# Altium schematic sheet RB_Audio.SchDoc(RB_Audio)
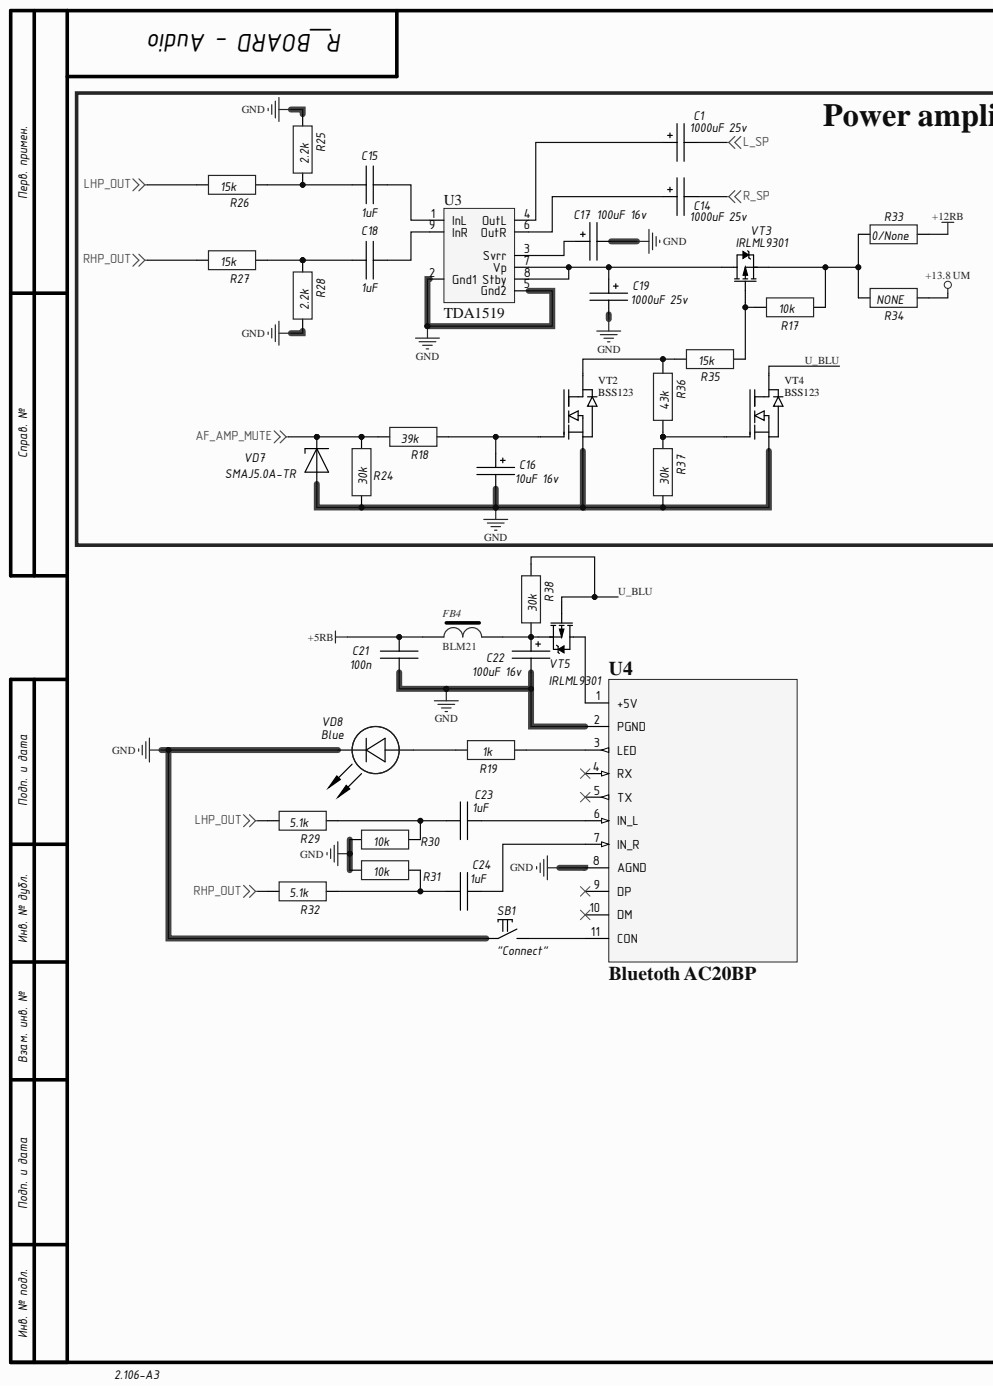
[diagram p0: RB_Audio.SchDoc, left]
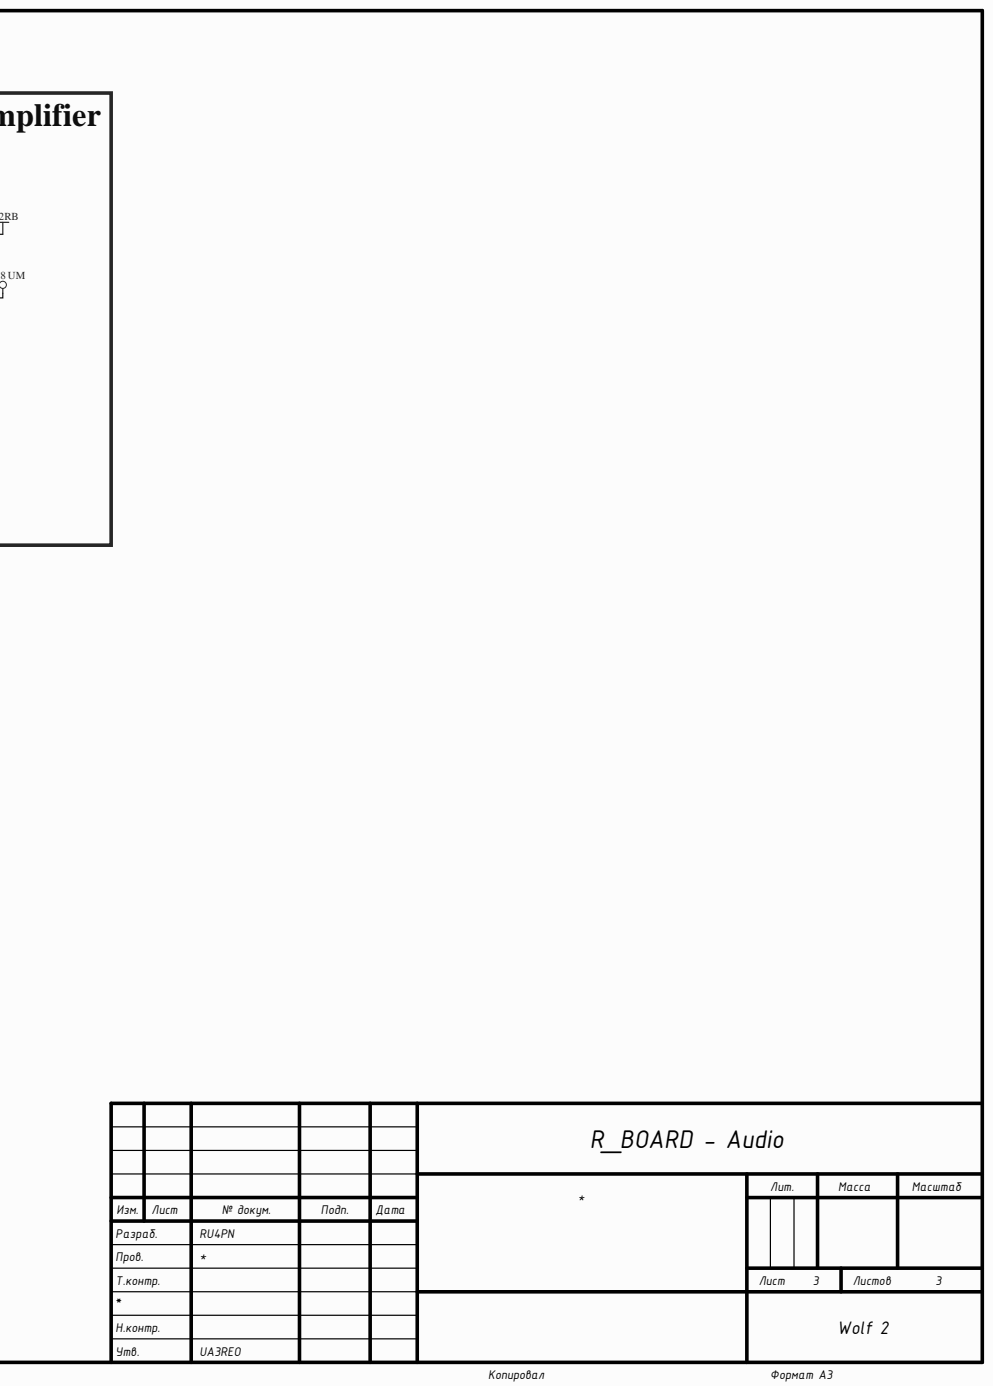
[diagram p1: RB_Audio.SchDoc, right]

## Parts
C1  1000uF 25v  [D10C5H12.5]
C14  1000uF 25v  [D10C5H12.5]
C15  1uF  [CH0805C]
C16  10uF 16v  [TANTAL_B]
C17  100uF 16v  [TANTAL_D]
C18  1uF  [CH0805C]
C19  1000uF 25v  [D10C5H12.5]
C21  100n  [CH0805C]
C22  100uF 16v  [D6.3C2.5H11]
C23  1uF  [CH0805C]
C24  1uF  [CH0805C]
FB4  BLM21  [CH0805L]
R17  10k  [CH0805R]
R18  39k  [CH0805R]
R19  1k  [CH0805R]
R24  30k  [CH0805R]
R25  2.2k  [CH0805R]
R26  15k  [CH0805R]
R27  15k  [CH0805R]
R28  2.2k  [CH0805R]
R29  5.1k  [CH0805R]
R30  10k  [CH0805R]
R31  10k  [CH0805R]
R32  5.1k  [CH0805R]
R33  0/None  [CH1206R]
R34  NONE  [CH1206R]
R35  15k  [CH0805R]
R36  43k  [CH0805R]
R37  30k  [CH0805R]
R38  30k  [CH0805R]
SB1  "Connect"  [BUT: 6x6 mm (R)]
U3  TDA1519  [SOT110B]
U4  Bluetoth AC20BP  [Bluetooth 11PIN]
VD7  SMAJ5.0A-TR  [SMA]
VD8  Blue  [CH0805LB]
VT2  BSS123  [SOT23_132]
VT3  IRLML9301  [SOT23_132]
VT4  BSS123  [SOT23_132]
VT5  IRLML9301  [SOT23_132]

## Nets
+5RB: C21.2 FB4.2
+12RB: R33.2
+13.8 UM: R34.2
AF_AMP_MUTE: R18.1 R24.2 VD7.2
GND: C16.2 C17.2 C19.2 C21.1 C22.2 R24.1 R25.2 R28.1 R30.1 R31.1 R37.1 SB1.1 U3.2(Gnd1) U3.5(Gnd2) U4.2(PGND) U4.8(AGND) VD7.1 VD8.2 VT2.2 VT4.2
L_SP: C1.2
LHP_OUT: R26.1 R29.1
R_SP: C14.2
RHP_OUT: R27.1 R32.1
U_BLU: R38.2 VT4.3 VT5.1
NetC1_1: C1.1 U3.4(OutL)
NetC14_1: C14.1 U3.6(OutR)
NetC15_1: C15.1 R25.1 R26.2
NetC15_2: C15.2 U3.1(InL)
NetC16_1: C16.1 R18.2 VT2.1
NetC17_1: C17.1 U3.3(Svrr)
NetC18_1: C18.1 R27.2 R28.2
NetC18_2: C18.2 U3.9(InR)
NetC19_1: C19.1 U3.7(Vp) U3.8(Stby) VT3.3
NetC22_1: C22.1 FB4.1 VT5.2
NetC23_1: C23.1 R29.2 R30.2
NetC23_2: C23.2 U4.6(IN_L)
NetC24_1: C24.1 R31.2 R32.2
NetC24_2: C24.2 U4.7(IN_R)
NetR17_1: R17.1 R35.2 VT3.1
NetR17_2: R17.2 R33.1 R34.1 VT3.2
NetR19_1: R19.1 VD8.1
NetR19_2: R19.2 U4.3(LED)
NetR35_1: R35.1 R36.2 VT2.3
NetR36_1: R36.1 R37.2 VT4.1
NetSB1_2: SB1.2 U4.11(CON)
NetU4_1: U4.1(+5V) VT5.3

Not connected: R38 1; U4 4(RX) 5(TX) 9(DP) 10(DM)

## Library data of the parts
C1 C14 C19 C22 = AlumCap: Component Kind: Standard; Library Name: Discrete.SchLib
C15 C18 C21 C23 C24 = Capacitor: Component Kind: Standard; Library Name: Discrete.SchLib
C16 C17 = TantalCap: Component Kind: Standard; Library Name: Discrete.SchLib
FB4 = FB-1: Component Kind: Standard; Library Name: Discrete.SchLib
R17-R19 R24-R38 = Resistor: Component Kind: Standard; Library Name: Discrete.SchLib
SB1 = Tactile switches: 6x6 mm; Component Kind: Standard; Library Name: Connectors.SchLib
U4 = Bluetoth AC20BP Module: Component Kind: Standard; Library Name: Module.SchLib
VD7 = Diod Zener: Component Kind: Standard; Library Name: Diods.SchLib
VD8 = LED: Component Kind: Standard; Library Name: Discrete.SchLib
VT3 VT5 = IRLML9301: Mosfet; Component Kind: Standard; Library Name: Transistor.SchLib
U3 = TDA1519: Component Kind: Standard; Library Name: Discrete.SchLib
VT2 VT4 = BSS123: Component Kind: Standard; Library Name: Transistor.SchLib
